# Altium schematic sheet Power Schematic.SchDoc
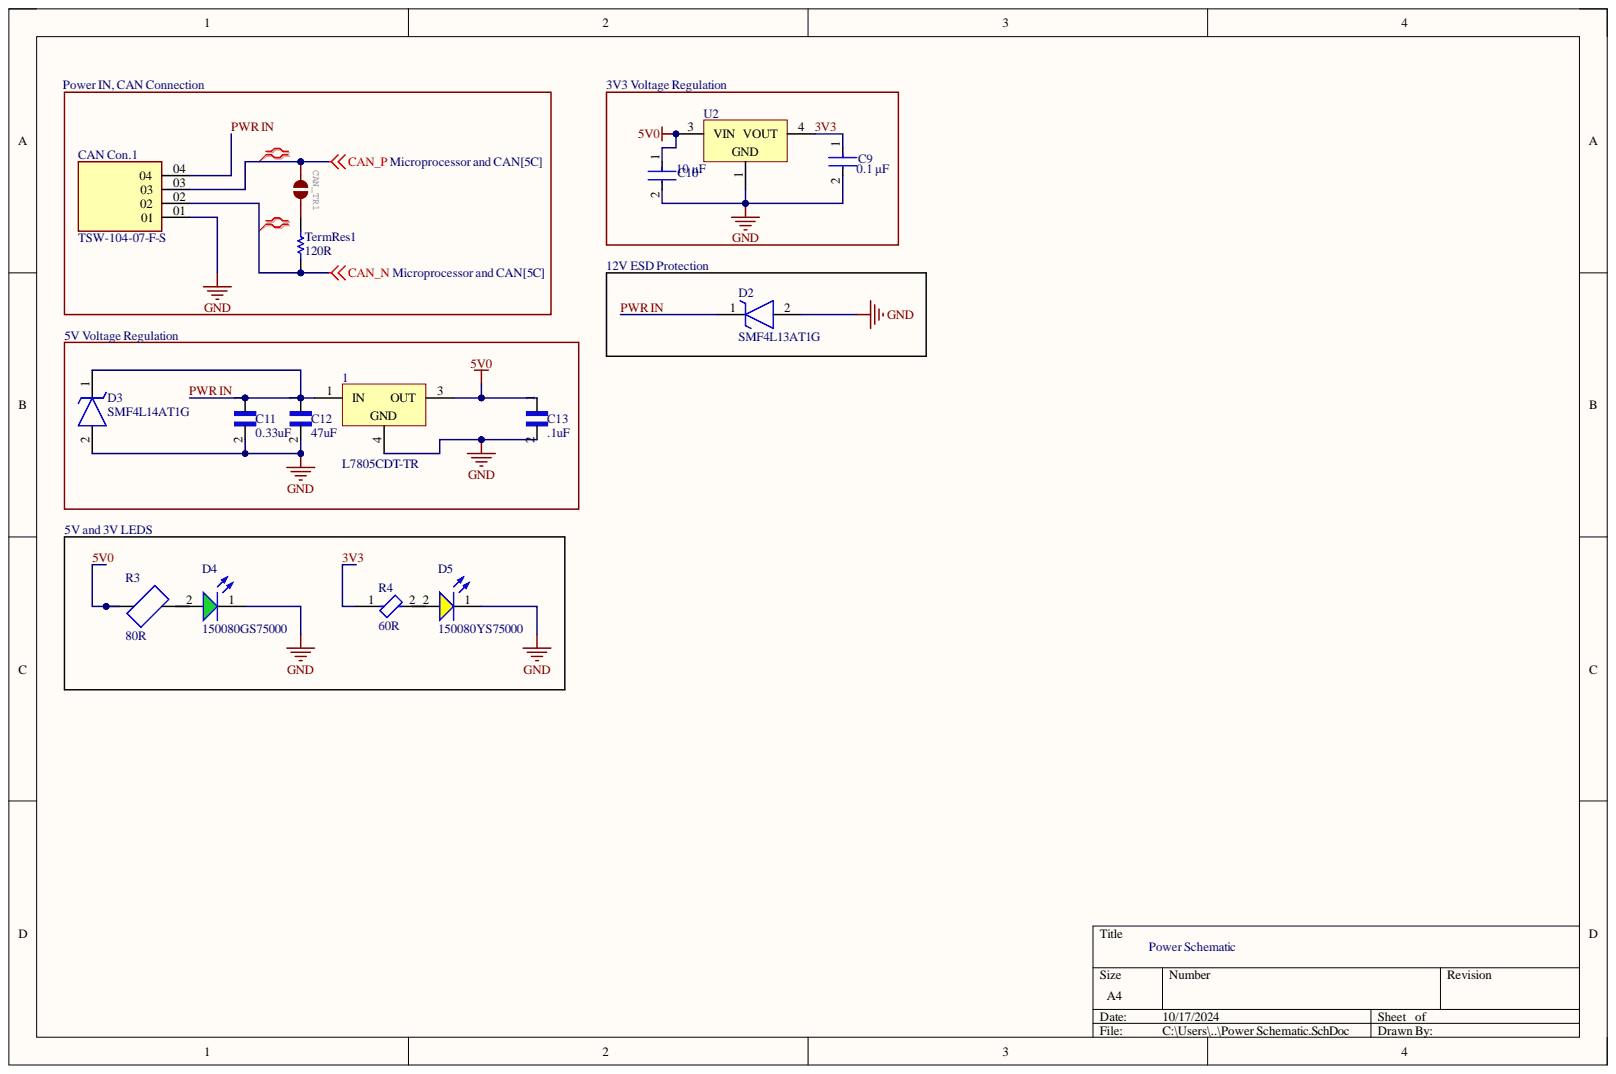
[diagram p0: Power Schematic.SchDoc]

## Parts
1  L7805CDT-TR  [TO-252_N]
C9  C0805C106K8PACTU  [FP-C0805C-DG-MFG]  (value not drawn)
C10  08051C104K4T2A  [FP-0805-L_2_01_0_2-W_1_25-IPC_B]  (value not drawn)
C11  0.33uF  [CAPC2012X95N]
C12  47uF  [CAPC3216X160N]
C13  .1uF  [CAPC2012X135N]
CAN_Con.1  TSW-104-07-F-S  [SAMTEC_TSW-104-07-F-S]
CAN_TR1  (no value)  [SMT-JUMPER_2_NO_SILK]
D2  SMF4L13AT1G  [SODFL3619X98N]
D3  SMF4L14AT1G  [SODFL3619X98N]
D4  150080GS75000  [WL-SMCW_0805_150080xx75000]
D5  150080YS75000  [WL-SMCW_0805_150080xx75000]
R3  80R  [FB_HI0805R800R-10]
R4  60R  [FP-BLM21-0_2-t0_85-e0_5-d-IPC_A]
TermRes1  120R  [RESC2013X60X40LL20T25]
U2  LD1117ADT33TR  [TO-252_L]  (value not drawn)

## Nets
CAN_N: CAN_Con.1.02 TermRes1.1
CAN_P: CAN_Con.1.03 CAN_TR1.1
GND: 1.4 C9.2 C10.2 C11.2 C12.2 C13.2 CAN_Con.1.01 D2.2 D3.2 D4.1 D5.1 U2.1
3V3: C9.1 R4.1 U2.4
5V0: 1.3 C10.1 C13.1 R3.1 U2.3
PWR IN: 1.1 C11.1 C12.1 CAN_Con.1.04 D2.1 D3.1
NetCAN_TR1_2: CAN_TR1.2 TermRes1.2

Not connected: D4 2; D5 2; R3 2; R4 2

## Library data of the parts
1 = CMP-0244-00216-1: Positive Voltage Regulator, 3-Pin DPAK, Tape and Reel; Component Kind: Standard; ComponentLink1Description: Manufacturer URL; ComponentLink1URL: http://www.st.com; ComponentLink2Description: Datasheet; ComponentLink2URL: http://www.st.com/internet/com/TECHNICAL_RESOURCES/TECHNICAL_LITERATURE/DATASHEET/CD00000444.pdf; DatasheetVersion: 16/11/2011; Library Name: Altium Content Vault; PackageDescription: 3-Pin Transistor Outline Package 2.28 mm Pitch, 9.7 mm Lead Span (DPAK); PackageReference: TO-252; PackageVersion: 2011
C9 = CMP-2007-03243-2: CAP CER 10UF 10V X5R 0805; 3DS Part Number: 220-00001; Manufacturer: Kemet; Material: Ceramic; Temperature Coefficient: 15%; Tolerance: 10%; Automotive: No; Capacitance: 10uF; Category: Cap; Component Kind: Standard; ComponentLink2Description: Datasheet; ComponentLink2URL: https://content.kemet.com/datasheets/KEM_C1006_X5R_SMD.pdf; Device Class L1: Passive Components; Device Class L2: Capacitors; Device Class L3: Ceramic Capacitors; Digikey Description: CAP CER 10UF 10V X5R 0805; Height: 1.4mm; Ipc Land Pattern Name: CAPC200125X125; Lead Free: Yes; Library Name: Altium Content Vault; Manufacturer Part Number: C0805C106K8PACTU; Mouser Description: CAP CER 10UF 10V X5R 0805; Octopart: https://octopart.com/c0805c106k8pactu-kemet-98697; Package: C0805C-DG; RoHS: TRUE; Temperature: -55°C to +85°C; Temperature Characteristic: X5R; Temperature Range High: +85°C; Temperature Range Low: -55°C; Value: 10uF; Voltage: 10V; Voltage Rating: 10V
C10 = CMP-2007-04172-2: Automotive Ceramic Capacitor, 0805, 100nF, 10%, X7R, 15%, 100V; Capacitance: 100nF; Case Code (Imperial): 0805; Case Code (Metric): 2012; Case/Package: 0805; Component Kind: Standard; Construction: Flat; Depth: 1.25mm; Dielectric: X7R; Dielectric Material: Ceramic; Height: 1.4mm; Lead Free: Lead Free; Length: 2.01mm; Library Name: Altium Content Vault; Max Operating Temperature: 125°C; Min Operating Temperature: -55°C; Mount: Surface Mount; Number of Pins: 2; Package Quantity: 1; Packaging: Tape and Reel; Radiation Hardening: No; Ratings: AEC-Q200; REACH SVHC: No SVHC; RoHS Compliant: Yes; Series: -; Termination: SMD/SMT; Thickness: 0.055inch; Tolerance: 10%; Voltage: 100V; Voltage Rating: 100V; Voltage Rating (DC): 100V; Width: 0.049inch
C11 = GCJ219R71H334KA12D: Cap Ceramic 0.33uF 50V X7R 10% SMD 0805 125Â°C Paper T/R; Availability: Unavailable; Component Kind: Standard; Library Name: GCJ219R71H334KA12D.IntLib; MP: GCJ219R71H334KA12D; Package: None; Price: None; Supplier 1: Mouser; Supplier Part Number 1: 81-GCJ219R71H334KA2D
C12 = GRM31CR61E476ME44K: 47 ÂµF Â±20% 25V Ceramic Capacitor X5R 1206 (3216 Metric); MF: Murata Electronics; Availability: In Stock; Check_prices: https://www.snapeda.com/parts/GRM31CR61E476ME44K/Murata+Electronics+North+America/view-part/?ref=eda; Component Kind: Standard; Library Name: GRM31CR61E476ME44K.IntLib; MP: GRM31CR61E476ME44K; Package: 1206 Murata; Price: None; Purchase-URL: https://pricing.snapeda.com/search?q=GRM31CR61E476ME44K&ref=eda; SnapEDA_Link: https://www.snapeda.com/parts/GRM31CR61E476ME44K/Murata+Electronics+North+America/view-part/?ref=snap; Supplier 1: Mouser; Supplier Part Number 1: 80-C1206C476M8P
C13 = GRM21BR72A104JAC4K: CAP 0.1UF 100VDC X7R 5% SMD 0805 EMBOSSED TAPE; Availability: Unavailable; Component Kind: Standard; Library Name: GRM21BR72A104JAC4K.IntLib; MP: GRM21BR72A104JAC4K; Package: None; Price: None; Supplier 1: Mouser; Supplier Part Number 1: 81-GRM21BR72A104JA4K
CAN_Con.1 = TSW-104-07-F-S: MF: Samtec; Availability: Unavailable; Component Kind: Standard; Library Name: TSW-104-07-F-S.IntLib; MP: TSW-104-07-F-S; Package: None; Price: None; Supplier 1: Digikey; Supplier Part Number 1: MTSW-104-07-F-S-170-ND
CAN_TR1 = JUMPER-SMT_2_NO_SILK: DeviceName: _SILK; GateName_1: G$1; SymbolName_1: SMT-JUMPER_2_NO; Component Kind: Standard; DeviceSetName: JUMPER-SMT_2_NO; Library Name: SparkFun-Jumpers.SCHLIB; LibraryName: SparkFun-Jumpers; Package: SMT-JUMPER_2_NO_SILK
D2 = SMF4L13AT1G: TVS Diode (Uni-directional); Manufacturer_Name: LITTELFUSE; Component Kind: Standard; Datasheet Link: https://www.littelfuse.com/media?resourcetype=datasheets&itemid=f093622a-2591-4e70-85e6-32321d2f8c5a&filename=tvs-diode-smf4l-t1g-datasheet; Height: 0.98mm; Manufacturer_Part_Number: SMF4L13AT1G; Mouser Part Number: 576-SMF4L13AT1G; Mouser Price/Stock: https://www.mouser.co.uk/ProductDetail/Littelfuse/SMF4L13AT1G?qs=OcgtsXO%252B3gst%2FkI5Xf9O6g%3D%3D
D3 = SMF4L14AT1G: TVS Diode (Uni-directional); Manufacturer_Name: LITTELFUSE; Component Kind: Standard; Datasheet Link: https://www.littelfuse.com/media?resourcetype=datasheets&itemid=f093622a-2591-4e70-85e6-32321d2f8c5a&filename=tvs-diode-smf4l-t1g-datasheet; Height: 0.98mm; Library Name: SamacSys.SchLib; Manufacturer_Part_Number: SMF4L14AT1G; Mouser Part Number: 576-SMF4L14AT1G; Mouser Price/Stock: https://www.mouser.co.uk/ProductDetail/Littelfuse/SMF4L14AT1G?qs=OcgtsXO%252B3gvCCebw4hBNNg%3D%3D; Supplier 1: Digikey; Supplier Part Number 1: 18-SZSMF4L14AT1GTR-ND
D4 = CMP-1426-00007-3: WURTH ELEKTRONIK - 150080GS75000 - LED, Green, SMD, 0805, 30 mA, 3.2 V, 520 nm; Dominant Wavelength: 525nm; Forward Current: 100mA; Forward Voltage: 3.2V; Lens Style: Clear, Rectangular; Luminous Intensity: 450mcd; Max Junction Temperature (Tj): 95°C; Max Reverse Voltage (DC): 5V; Peak Wavelength: 515nm; Power Dissipation: 105mW; Test Current: 20mA; Viewing Angle: 140°; Case Code (Imperial): 0805; Case Code (Metric): 2012; Case/Package: 0805; Color: Green; Component Kind: Standard; Height: 700µm; Length: 2mm; Library Name: Altium Content Vault; Max Operating Temperature: 85°C; Min Operating Temperature: -40°C; Mount: Surface Mount; Packaging: Tape and Reel; REACH SVHC: Unknown; Reverse Voltage: 5V; RoHS: Compliant; Wavelength: 525nm; Width: 1.25mm
D5 = CMP-1426-00012-3: 2 V Yellow LED 2012 (0805) SMD, Wurth Elektronik WL-SMCW 150080YS75000; Dominant Wavelength: 590nm; Forward Current: 100mA; Forward Voltage: 2V; Lens Style: Clear, Rectangular; Luminous Intensity: 120mcd; Max Junction Temperature (Tj): 95°C; Max Reverse Voltage (DC): 5V; Peak Wavelength: 595nm; Power Dissipation: 72mW; Test Current: 20mA; Viewing Angle: 140°; Case Code (Imperial): 0805; Case Code (Metric): 2012; Case/Package: 0805; Color: Yellow; Component Kind: Standard; Height: 700µm; Length: 2mm; Library Name: Altium Content Vault; Max Operating Temperature: 85°C; Min Operating Temperature: -40°C; Mount: Surface Mount; Packaging: Tape and Reel; REACH SVHC: Unknown; Reverse Voltage: 5V; RoHS: Compliant; Wavelength: 590nm; Width: 1.25mm
R3 = HI0805R800R-10: MF: {'manufacturer': u'Laird-Signal Integrity Products'}; Availability: Unavailable; Component Kind: Standard; MP: HI0805R800R-10; Package: None; Price: None
R4 = CMP-0686-00616-3: Chip Ferrite Bead, 0805, 60Ω @ 100MHz, 0.02Ω, 25%, 3.5A; DC Current: 3A; DC Resistance (DCR): 20mR; Number of Circuits: 1; Shielding: Unshielded; Case Code (Imperial): 0805; Case Code (Metric): 2012; Case/Package: 0805; Component Kind: Standard; Core Material: Ferrite; Current Rating: 3.5A; DC Resistance-Max: 20mR; Depth: 1.25mm; Frequency: 100MHz; Height: 0.85mm; Impedance: 60R; Lead Free: Lead Free; Length: 2mm; Library Name: Altium Content Vault; Material: Steel; Max Current Rating: 3.5A; Max DC Current: 3A; Max Operating Temperature: 125°C; Military Standard: Not; Min Operating Temperature: -55°C; Number of Lines: 1; Number of Pins: 2; Package Quantity: 1; Packaging: Tape and Reel; Radiation Hardening: No; REACH SVHC: No SVHC; RoHS Compliant: Yes; Series: BLM21P; Termination: SMD/SMT; Test Frequency: 100MHz; Tolerance: 25%; Width: 1.25mm
TermRes1 = CMP-2001-00645-1: Manufacturer: Panasonic; Part Number: ERA6AEB473V; Component Kind: Standard; Library Name: Altium Content Vault
U2 = CMP-0244-00137-1: 1A, Low Drop Positive Voltage Regulator, 3.3V, 3-Pin DPAK, Tape and Reel; Component Kind: Standard; ComponentLink1Description: Manufacturer URL; ComponentLink1URL: http://www.st.com; ComponentLink2Description: Datasheet; ComponentLink2URL: http://www.st.com/internet/com/TECHNICAL_RESOURCES/TECHNICAL_LITERATURE/DATASHEET/CD00002116.pdf; DatasheetVersion: 2/12/2011; Footprint 1: TO-252-3; Library Name: Altium Content Vault; Manufacturer PN: LD1117ADT33TR; PackageDescription: 3-Pin Transistor Outline Package 2.28 mm Pitch, 9.7 mm Lead Span (DPAK); PackageReference: TO-252; PackageVersion: 2011
